# Altium schematic sheet PB1000.SchDoc(PB1000)
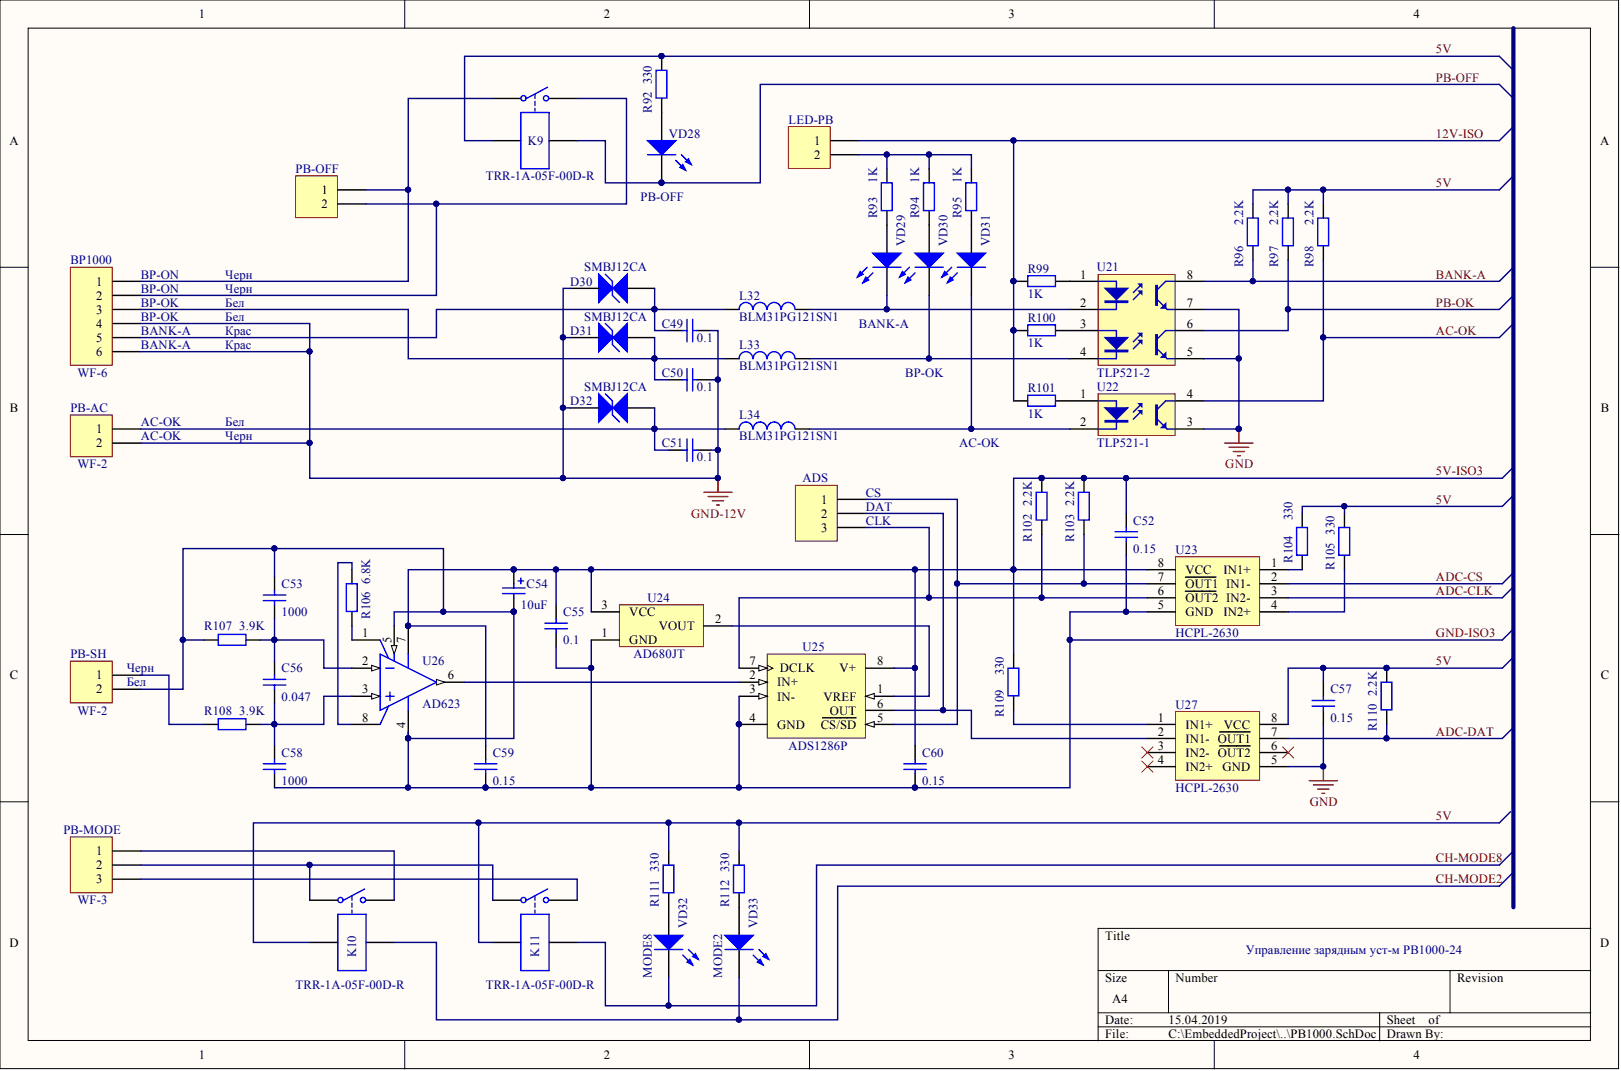
[diagram p0: PB1000.SchDoc]

## Parts
ADS  Header 3  [HDR1X3]  (value not drawn)
BP1000  value WF-6  [WF-6]  (hidden comment: MHDR1X6)
C49  value 0.1  [C1206]  (hidden comment: Cap)
C50  value 0.1  [C1206]  (hidden comment: Cap)
C51  value 0.1  [C1206]  (hidden comment: Cap)
C52  value 0.15  [C1206]  (hidden comment: Cap)
C53  value 1000  [C1206]  (hidden comment: Cap)
C54  value 10uF  [D]  (hidden comment: Polarized Capacitor)
C55  value 0.1  [C1206]  (hidden comment: Cap)
C56  value 0.047  [C1206]  (hidden comment: Cap)
C57  value 0.15  [C1206]  (hidden comment: Cap)
C58  value 1000  [C1206]  (hidden comment: Cap)
C59  value 0.15  [C1206]  (hidden comment: Cap)
C60  value 0.15  [C1206]  (hidden comment: Cap)
D30  SMBJ12CA  [DO-214AA]
D31  SMBJ12CA  [DO-214AA]
D32  SMBJ12CA  [DO-214AA]
K9  value TRR-1A-05F-00D-R  [TRR-1A-05F-00D-R]  (hidden comment: Single-Pole Single-Throw Relay)
K10  value TRR-1A-05F-00D-R  [TRR-1A-05F-00D-R]  (hidden comment: Single-Pole Single-Throw Relay)
K11  value TRR-1A-05F-00D-R  [TRR-1A-05F-00D-R]  (hidden comment: Single-Pole Single-Throw Relay)
L32  value BLM31PG121SN1  [L1206]  (hidden comment: Ferrite Chip Beads)
L33  value BLM31PG121SN1  [L1206]  (hidden comment: Ferrite Chip Beads)
L34  value BLM31PG121SN1  [L1206]  (hidden comment: Ferrite Chip Beads)
LED-PB  JP2  [HDR1X2]  (value not drawn)
PB-AC  value WF-2  [WF-2]  (hidden comment: Header, 2-Pin)
PB-MODE  value WF-3  [WF-3]  (hidden comment: Header, 3-Pin)
PB-OFF  Header 2  [HDR1X2]  (value not drawn)
PB-SH  value WF-2  [WF-2]  (hidden comment: Header, 2-Pin)
R92  value 330  [1206]  (hidden comment: Res2)
R93  value 1K  [1206]  (hidden comment: Res2)
R94  value 1K  [1206]  (hidden comment: Res2)
R95  value 1K  [1206]  (hidden comment: Res2)
R96  value 2.2K  [1206]  (hidden comment: Res2)
R97  value 2.2K  [1206]  (hidden comment: Res2)
R98  value 2.2K  [1206]  (hidden comment: Res2)
R99  value 1K  [1206]  (hidden comment: Res2)
R100  value 1K  [1206]  (hidden comment: Res2)
R101  value 1K  [1206]  (hidden comment: Res2)
R102  value 2.2K  [1206]  (hidden comment: Res2)
R103  value 2.2K  [1206]  (hidden comment: Res2)
R104  value 330  [1206]  (hidden comment: Res2)
R105  value 330  [1206]  (hidden comment: Res2)
R106  value 6.8K  [1206]  (hidden comment: Res2)
R107  value 3.9K  [1206]  (hidden comment: Res2)
R108  value 3.9K  [1206]  (hidden comment: Res2)
R109  value 330  [1206]  (hidden comment: Res2)
R110  value 2.2K  [1206]  (hidden comment: Res2)
R111  value 330  [1206]  (hidden comment: Res2)
R112  value 330  [1206]  (hidden comment: Res2)
U21  TLP521-2  [DIP-8 - OPTO]
U22  TLP521-1  [DIP-4 - OPTO]
U23  HCPL-2630  [DIP-8]
U24  value AD680JT  [AD680JT TO-92]  (hidden comment: Voltage References)
U25  value ADS1286P  [DIP-8]  (hidden comment: 12-Bit Micro Power Sampling Analog-to-Digital Converter)
U26  value AD623  [DIP8]  (hidden comment: Single-Supply, Rail-to-Rail, Low Cost  Instrumentation Amplifier)
U27  HCPL-2630  [DIP-8]
VD28  PB-OFF  [LED1206 - RED]
VD29  BANK-A  [LED1206 - GREEN]
VD30  BP-OK  [LED1206 - GREEN]
VD31  AC-OK  [LED1206 - GREEN]  (value not drawn)
VD32  MODE8  [LED1206 - RED]
VD33  MODE2  [LED1206 - RED]

## Nets
GND: C57.2 U21.5 U21.7 U22.3 U27.5(GND)
GND-12V: BP1000.4 BP1000.6 C49.2 C50.2 C51.2 D30.2 D31.2 D32.2 PB-AC.2
5V: C57.1 K9.3 K10.3 K11.3 R92.1 R96.1 R97.1 R98.1 R104.1 R105.1 R110.1 R111.1 R112.1 U27.8(VCC)
5V-ISO3: C52.1 C54.1 C55.1 C59.1 C60.1 R102.1 R103.1 R109.1 U23.8(VCC) U24.3 U25.8(V+) U26.7
12V-ISO: LED-PB.1 R99.1 R100.1 R101.1
AC-OK: R98.2 U22.4
ADC-CLK: U23.3(IN2-)
ADC-CS: U23.2(IN1-)
ADC-DAT: R110.2 U27.7(OUT1)
BANK-A: R96.2 U21.8
CH-MODE2: K10.5 VD33.2
CH-MODE8: K11.5 VD32.2
GND-ISO3: C52.2 C53.1 C54.2 C55.2 C58.2 C59.2 C60.2 PB-SH.2 R107.1 U23.5(GND) U24.1 U25.3(IN-) U25.4(GND) U26.4 U26.5
PB-OFF: K9.5 VD28.2
PB-OK: R97.2 U21.6
NetADS_1: ADS.1 R103.2 U23.7(OUT1) U25.5(CS/SD)
NetADS_2: ADS.2 U25.6(OUT) U27.2(IN1-)
NetADS_3: ADS.3 R102.2 U23.6(OUT2) U25.7(DCLK)
NetBP1000_1: BP1000.1 K9.1 PB-OFF.1
NetBP1000_2: BP1000.2 K9.7 PB-OFF.2
NetBP1000_3: BP1000.3 C50.1 D31.1 L33.1
NetBP1000_5: BP1000.5 C49.1 D30.1 L32.1
NetC51_1: C51.1 D32.1 L34.1 PB-AC.1
NetC53_2: C53.2 C56.1 R107.2 U26.2
NetC56_2: C56.2 C58.1 R108.2 U26.3
NetK10_1: K10.1 K11.1 PB-MODE.2
NetK10_7: K10.7 PB-MODE.1
NetK11_7: K11.7 PB-MODE.3
NetL32_2: L32.2 U21.2 VD29.2
NetL33_2: L33.2 U21.4 VD30.2
NetL34_2: L34.2 U22.2 VD31.2
NetLED-PB_2: LED-PB.2 R93.2 R94.2 R95.2
NetPB-SH_1: PB-SH.1 R108.1
NetR92_2: R92.2 VD28.1
NetR93_1: R93.1 VD29.1
NetR94_1: R94.1 VD30.1
NetR95_1: R95.1 VD31.1
NetR99_2: R99.2 U21.1
NetR100_2: R100.2 U21.3
NetR101_2: R101.2 U22.1
NetR104_2: R104.2 U23.1(IN1+)
NetR105_2: R105.2 U23.4(IN2+)
NetR106_1: R106.1 U26.1
NetR106_2: R106.2 U26.8
NetR109_2: R109.2 U27.1(IN1+)
NetR111_2: R111.2 VD32.1
NetR112_2: R112.2 VD33.1
NetU24_2: U24.2 U25.1(VREF)
NetU25_2: U25.2(IN+) U26.6
N00509:

Not connected: U27 3(IN2-) 4(IN2+) 6(OUT2)

## Library data of the parts
ADS = Header 3: Header, 3-Pin; Component Kind: Standard; LatestRevisionDate: 17-Jul-2002; LatestRevisionNote: Re-released for DXP Platform.; Library Name: Miscellaneous Connectors.IntLib; Signal Integrity: Connector; value: Header 3; Publisher: Altium Limited
BP1000 = MHDR1X6: Header, 6-Pin; Component Kind: Standard; LatestRevisionDate: 17-Jul-2002; LatestRevisionNote: Re-released for DXP Platform.; Library Name: Miscellaneous Connectors.IntLib; Signal Integrity: Connector; Publisher: Altium Limited
C49-C53 C55-C60 = Cap: Capacitor; Component Kind: Standard; LatestRevisionDate: 17-Jul-2002; LatestRevisionNote: Re-released for DXP Platform.; Library Name: Miscellaneous Devices.IntLib; PackageReference: RAD-0.3; Published: 8-Jun-2000; Signal Integrity: Cap; Simulation: CAP; Publisher: Altium Limited
C54 = Cap Pol2: Component Kind: Standard; Description: Polarized Capacitor; LatestRevisionDate: 17-Jul-2002; LatestRevisionNote: Re-released for DXP Platform.; Library Name: Miscellaneous Devices.IntLib; PackageReference: POLAR0.8; Published: 8-Jun-2000; Signal Integrity: Cap Pol; Simulation: CAP; Publisher: Altium Limited
D30-D32 = SMBJ12CA: Voltage suppressor; Component Kind: Standard; Library Name: Schlib1.SchLib; Value: SMBJ12CA
K9-K11 = TRR-1A-05F-00D-R: Component Kind: Standard; Description: Single-Pole Single-Throw Relay; LatestRevisionDate: 17-Jul-2002; LatestRevisionNote: Re-released for DXP Platform.; Library Name: Schlib1.SchLib; PackageReference: MODULE4; Published: 24-Mar-1999; Simulation: SPSTRELAY; Publisher: Altium Limited
L32-L34 = Inductor: Code_IEC: 1005; Code_JEITA: 0402; Component Kind: Standard; Description: Ferrite Chip Beads; LatestRevisionDate: 29-May-2009; LatestRevisionNote: IPC-7351 Footprint Added.; Library Name: Miscellaneous Devices.IntLib; PackageDescription: Chip Inductor; PackageReference: 0402-A; Published: 8-Jun-2000; Signal Integrity: Inductor; Simulation: INDUCTOR; Publisher: Altium Limited
LED-PB PB-OFF = Header 2: Header, 2-Pin; value: Jumper; Component Kind: Standard; LatestRevisionDate: 17-Jul-2002; LatestRevisionNote: Re-released for DXP Platform.; Library Name: Miscellaneous Connectors.IntLib; Signal Integrity: Connector; Publisher: Altium Limited
PB-AC PB-SH = Header 2: Component Kind: Standard; Description: Header, 2-Pin; LatestRevisionDate: 17-Jul-2002; LatestRevisionNote: Re-released for DXP Platform.; Library Name: Miscellaneous Connectors.IntLib; Signal Integrity: Connector; Publisher: Altium Limited
PB-MODE = Header 3: Component Kind: Standard; Description: Header, 3-Pin; LatestRevisionDate: 17-Jul-2002; LatestRevisionNote: Re-released for DXP Platform.; Library Name: Miscellaneous Connectors.IntLib; Signal Integrity: Connector; Publisher: Altium Limited
R92-R112 = Res2: Resistor; Component Kind: Standard; LatestRevisionDate: 17-Jul-2002; LatestRevisionNote: Re-released for DXP Platform.; Library Name: Miscellaneous Devices.IntLib; PackageReference: AXIAL-0.4; Published: 8-Jun-2000; Signal Integrity: Res; Simulation: RESISTOR; Publisher: Altium Limited
U21 = TLP521-2: 8-Pin Phototransistor Optocoupler; Component Kind: Standard; DatasheetVersion: Nov-2003; LatestRevisionDate: 04-Mar-2005; LatestRevisionNote: Sch pins 3 & 4 Swapped.; Library Name: Schlib1.SchLib; PackageReference: DIP-4; Published: 24-Mar-1999; Value: TLP521-2; Publisher: Altium Limited
U22 = TLP521-1: 1 consist of a photo−transistor; Component Kind: Standard; DatasheetVersion: Nov-2003; LatestRevisionDate: 04-Mar-2005; LatestRevisionNote: Sch pins 3 & 4 Swapped.; Library Name: Schlib1.SchLib; PackageReference: DIP-4; Published: 24-Mar-1999; Value: TLP521-1; Publisher: Altium Limited
U23 U27 = HCPL-2630: High speed-10 mbit/s logic gate optocouplers dual-channel; Component Kind: Standard; Library Name: Schlib1.SchLib; Value: HCPL-2630
U24 = AD680JT: Component Kind: Standard; Description: Voltage References; Library Name: Schlib1.SchLib
U25 = ADS1286P: Component Kind: Standard; ComponentLink1Description: Manufacturer Link; ComponentLink1URL: http://www.ti.com/; ComponentLink2Description: Datasheet; ComponentLink2URL: http://www-s.ti.com/sc/ds/ads1286.pdf; DatasheetVersion: Oct-1998; Description: 12-Bit Micro Power Sampling Analog-to-Digital Converter; LatestRevisionDate: 06-Jun-2005; LatestRevisionNote: Stylized 3D Model Added.; Library Name: BB Converter Analog to Digital.IntLib; PackageDescription: DIP; 8 Leads; Row Spacing 7.62 mm; Pitch 2.54 mm; PackageReference: 006E; PackageVersion: revE; PCB3D: DIP8; Published: 8-Jun-2000; Publisher: Altium Limited
U26 = AD623: Manufacturer: Analog Devices; PartNumber: ADCMP602BRMZ; Input Range (V): -0.2 to VCC+0.2; Packing: Tube; Supply Current: 3 to 3.5mA; Voltage Supply (V): 2.5 to 5.5; Code_JEDEC: MO-187-AA; Component Kind: Standard; ComponentLink1Description: Manufacturer URL; ComponentLink1URL: http://www.analog.com/en/index.html; ComponentLink2Description: Datasheet; ComponentLink2URL: http://www.analog.com/static/imported-files/data_sheets/ADCMP600_601_602.pdf; ComponentLink3Description: Package Specification; ComponentLink3URL: http://www.analog.com/static/imported-files/packages/PKG_PDF/MSOP%28RM%29/RM_8.pdf; ComponentLink4Description: URL Link1; ComponentLink4URL: Product URL:http://www.analog.com/en/special-linear-functions/comparators/adcmp602/products/product.html; DatasheetVersion: Rev. A; Description: Single-Supply, Rail-to-Rail, Low Cost  Instrumentation Amplifier; Library Name: Schlib1.SchLib; Mounting Technology: Surface Mount; PackageDescription: 8-Pin Mini Small Outline Package, Body 3 x 3 mm, Pitch 0.65 mm; PackageReference: RM-8; PackageVersion: Aug-05; PCB3D: DIP-8; Supplier 1: Digi-Key; Supplier 2: Farnell; Supplier 3: Mouser; Supplier Part Number 1: ADCMP602BRMZ-ND; Supplier Part Number 2: 1331026; Supplier Part Number 3: 584-ADCMP602BRMZ
VD28 VD32 VD33 = LED0: SMD LED; value: RED; Component Kind: Standard; LatestRevisionDate: 08-Jul-2005; LatestRevisionNote: Mech Layer 1 removed.; Library Name: Miscellaneous Devices.IntLib; PackageReference: LED-0; Published: 8-Jun-2000; Simulation: LED0; Publisher: Altium Limited
VD29-VD31 = LED0: SMD LED; value: GREEN; Component Kind: Standard; LatestRevisionDate: 08-Jul-2005; LatestRevisionNote: Mech Layer 1 removed.; Library Name: Miscellaneous Devices.IntLib; PackageReference: LED-0; Published: 8-Jun-2000; Simulation: LED0; Publisher: Altium Limited
